# Altium schematic sheet Sch-QWA17_LAN.SchDoc(Sch-QWA17_LAN)
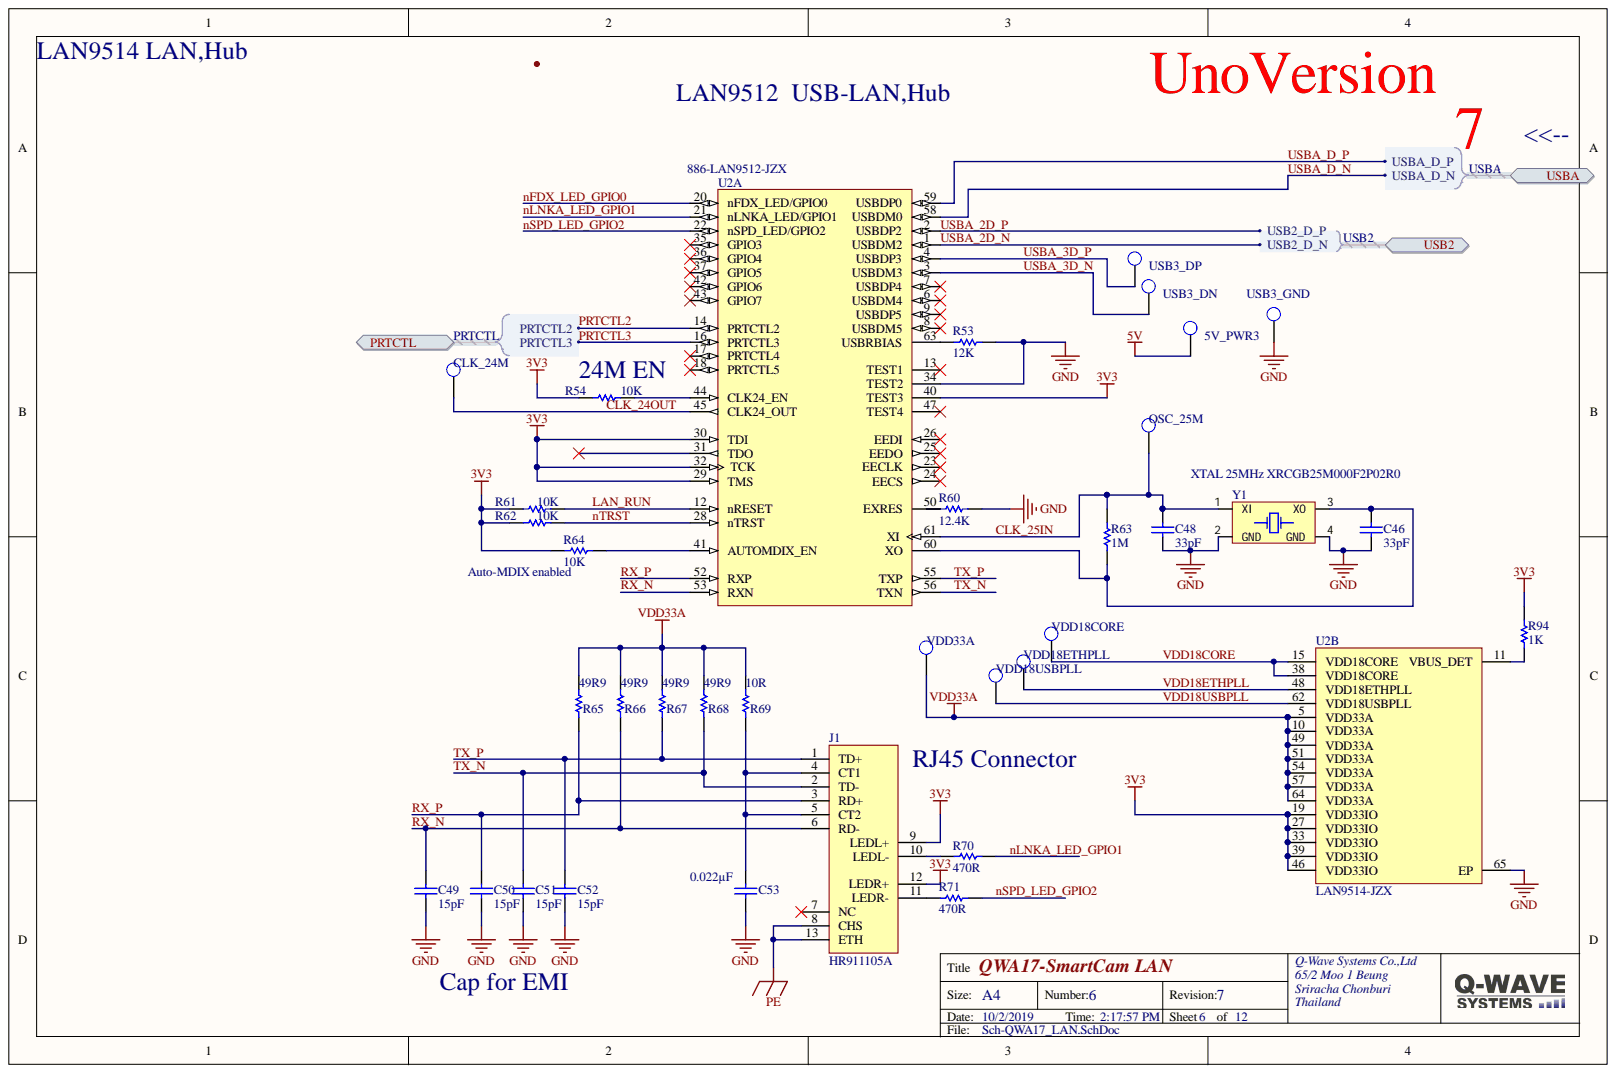
[diagram p0: Sch-QWA17_LAN.SchDoc]

## Parts
5V_PWR3  5V_PWR3  [TEST_POINT]
C46  33pF  [CAP_0603]
C48  33pF  [CAP_0603]
C49  15pF  [CAP_0603]
C50  15pF  [CAP_0603]
C51  15pF  [CAP_0603]
C52  15pF  [CAP_0603]
C53  0.022µF  [CAP_0603]
CLK_24M  CLK_24M  [TEST_POINT]
J1  HR911105A  [HY911105A]
OSC_25M  OSC_25M  [TEST_POINT]
R53  12K  [RES_0603]
R54  10K  [RES_0603]
R60  12.4K  [RES_0603]
R61  10K  [RES_0603]
R62  10K  [RES_0603]
R63  1M  [RES_0603]
R64  10K  [RES_0603]
R65  49R9  [RES_0603]
R66  49R9  [RES_0603]
R67  49R9  [RES_0603]
R68  49R9  [RES_0603]
R69  10R  [RES_0603]
R70  470R  [RES_0603]
R71  470R  [RES_0603]
R94  1K  [RES_0603]
U2  LAN9514-JZX  Supplier Part Number 1: 886-LAN9512-JZX  [_LAN9514]  (parts A,B)
USB3_DN  USB3_DN  [TEST_POINT]
USB3_DP  USB3_DP  [TEST_POINT]
USB3_GND  USB3_GND  [TEST_POINT]
VDD18CORE  VDD18CORE  [TEST_POINT]
VDD18ETHPLL  VDD18ETHPLL  [TEST_POINT]
VDD18USBPLL  VDD18USBPLL  [TEST_POINT]
VDD33A  VDD33A  [TEST_POINT]
Y1  XTAL 25MHz XRCGB25M000F2P02R0  [XT_2016_4]

## Ports
PRTCTL, PRTCTL.PRTCTL2, PRTCTL.PRTCTL3, USB2, USB2.USB2_D_N, USB2.USB2_D_P, USBA, USBA.USBA_D_N, USBA.USBA_D_P

## Nets
3V3: J1.9(LEDL+) J1.12(LEDR+) R54.1 R61.1 R62.1 R64.1 R94.1 U2.19(VDD33IO) U2.27(VDD33IO) U2.29(TMS) U2.30(TDI) U2.32(TCK) U2.33(VDD33IO) U2.39(VDD33IO) U2.40(TEST3) U2.46(VDD33IO)
5V: 5V_PWR3.1
GND: C46.2 C48.1 C49.2 C50.2 C51.2 C52.2 C53.1 R53.2 R60.2 U2.34(TEST2) U2.65(EP) USB3_GND.1 Y1.2(GND) Y1.4(GND)
PE: J1.8(CHS) J1.13(ETH)
VDD33A: R65.1 R66.1 R67.1 R68.1 R69.1 U2.5(VDD33A) U2.10(VDD33A) U2.49(VDD33A) U2.51(VDD33A) U2.54(VDD33A) U2.57(VDD33A) U2.64(VDD33A) VDD33A.1
CLK_24OUT: CLK_24M.1 U2.45(CLK24_OUT)
CLK_25IN: C48.2 OSC_25M.1 R63.2 U2.61(XI) Y1.1(XI)
LAN_RUN: R61.2 U2.12(nRESET)
NFDX_LED_GPIO0: U2.20(nFDX_LED/GPIO0)
NLNKA_LED_GPIO1: R70.2 U2.21(nLNKA_LED/GPIO1)
NSPD_LED_GPIO2: R71.2 U2.22(nSPD_LED/GPIO2)
NTRST: R62.2 U2.28(nTRST)
PRTCTL2: U2.14(PRTCTL2) ; port PRTCTL
PRTCTL3: U2.16(PRTCTL3) ; port PRTCTL
RX_N: C49.1 J1.6(RD-) R66.2 U2.53(RXN)
RX_P: C50.1 J1.3(RD+) R65.2 U2.52(RXP)
TX_N: C51.1 J1.2(TD-) R68.2 U2.56(TXN)
TX_P: C52.1 J1.1(TD+) R67.2 U2.55(TXP)
USBA_2D_N: U2.1(USBDM2) ; port USB2
USBA_2D_P: U2.2(USBDP2) ; port USB2
USBA_3D_N: U2.3(USBDM3) USB3_DN.1
USBA_3D_P: U2.4(USBDP3) USB3_DP.1
USBA_D_N: U2.58(USBDM0) ; port USBA
USBA_D_P: U2.59(USBDP0) ; port USBA
VDD18CORE: U2.15(VDD18CORE) U2.38(VDD18CORE) VDD18CORE.1
VDD18ETHPLL: U2.48(VDD18ETHPLL) VDD18ETHPLL.1
VDD18USBPLL: U2.62(VDD18USBPLL) VDD18USBPLL.1
NetC46_1: C46.1 R63.1 U2.60(XO) Y1.3(XO)
NetC53_2: C53.2 J1.4(CT1) J1.5(CT2) R69.2
NetJ1_10: J1.10(LEDL-) R70.1
NetJ1_11: J1.11(LEDR-) R71.1
NetR53_1: R53.1 U2.63(USBRBIAS)
NetR54_2: R54.2 U2.44(CLK24_EN)
NetR60_1: R60.1 U2.50(EXRES)
NetR64_2: R64.2 U2.41(AUTOMDIX_EN)
NetR94_2: R94.2 U2.11(VBUS_DET)

Not connected: J1 7(NC); U2 6(USBDM4) 7(USBDP4) 8(USBDM5) 9(USBDP5) 13(TEST1) 17(PRTCTL4) 18(PRTCTL5) 23(EECLK) 24(EECS) 25(EEDO) 26(EEDI) 31(TDO) 35(GPIO3) 36(GPIO4) 37(GPIO5) 42(GPIO6) 43(GPIO7) 47(TEST4)

## Library data of the parts
5V_PWR3 CLK_24M OSC_25M USB3_DN USB3_DP USB3_GND VDD18CORE VDD18ETHPLL VDD18USBPLL VDD33A = TEST_POINT: Test Point; Component Kind: Standard; Library Name: Misc.SCHLIB
C46 C48 = Cap Semi: 33pF ±5% 50V Ceramic Capacitor C0G, NP0 0603; MFG: KEMET; Component Kind: Standard; Library Name: Miscellaneous Devices.IntLib; Simulation: CAP
C49-C52 = Cap Semi: 15pF ±5% 50V Ceramic Capacitor C0G, NP0 0603; MFG: KEMET; Component Kind: Standard; Library Name: Miscellaneous Devices.IntLib; Simulation: CAP
C53 = Cap Semi: 0.01µF ±5% 50V Ceramic Capacitor X7R 0603; MFG: KEMET; Component Kind: Standard; Library Name: Miscellaneous Devices.IntLib; Simulation: CAP
J1 = HR911105A_1: RJ45_HANRUN_HY911105A; Component Kind: Standard; Library Name: RJ45_HANRUN_HY911105A.IntLib
R53 R54 R60-R64 R94 = Res Semi: Resistor 0603; Component Kind: Standard; Library Name: Miscellaneous Devices.IntLib; Simulation: RES
R65-R68 = CMP-1012-00363-1: 49R9 0.1W 1% 0603 (1608 Metric)  SMD; Case-EIA: 0603; Case-Metric: 1608; Value: 49.90 Ohm; Component Kind: Standard; Library Name: Altium Content Vault; Power: 0.1 W; Technology: SMT; Tolerance: 1 %
R69 = CMP-1012-00363-1: 10R 0.1W 1% 0603 (1608 Metric)  SMD; Case-EIA: 0603; Case-Metric: 1608; Value: 10 Ohm; Component Kind: Standard; Library Name: Altium Content Vault; Power: 0.1 W; Technology: SMT; Tolerance: 1 %
R70 R71 = CMP-1012-00363-1: 470R 0.1W 1% 0603 (1608 Metric)  SMD; Case-EIA: 0603; Case-Metric: 1608; Component Kind: Standard; Library Name: Altium Content Vault; Power: 0.1 W; Technology: SMT; Tolerance: 1 %; Value: 470R
U2 = CMP-1537-00002-1: USB 2.0 Hub and 10/100 Ethernet Controller, 3.3 V, 0 to 70 degC, 64-Pin QFN, RoHS, Tray; Manufacturer: Microchip; Downstreamm USB Ports: 4; Interface: USB 2.0; Supply Voltage: 3.3 V; Component Kind: Standard; ComponentLink1Description: Manufacturer URL; ComponentLink1URL: www.microchip.com/; ComponentLink2Description: Datasheet; ComponentLink2URL: http://ww1.microchip.com/downloads/en/DeviceDoc/9514.pdf; ComponentLink3Description: Package Specification; ComponentLink3URL: http://ww1.microchip.com/downloads/en/DeviceDoc/9514.pdf; DatasheetVersion: Rev. 1.2; Library Name: Altium Content Vault; Mounting Technology: Surface Mount; PackageDescription: 64-Pin Quad Flat Non-Leaded Package, Body 9 x 9 mm, Pitch 0.5 mm; Packing: Tray; PartNumber: LAN9514-JZX; RoHS: TRUE; Supplier 1: Mouser; Supplier 2: Mouser; Supplier Part Number 2: 886-LAN9512I-JZX; Temperature Range: 0 to 70 degC
Y1 = XTAL_2016_4P: Crystal Oscillator; Value: ?MHz; Component Kind: Standard; Library Name: SCH_Oscillator.SchLib; Type: XTAL2016
